# Altium schematic sheet FPGA_PWR.SchDoc(FPGA_PWR)
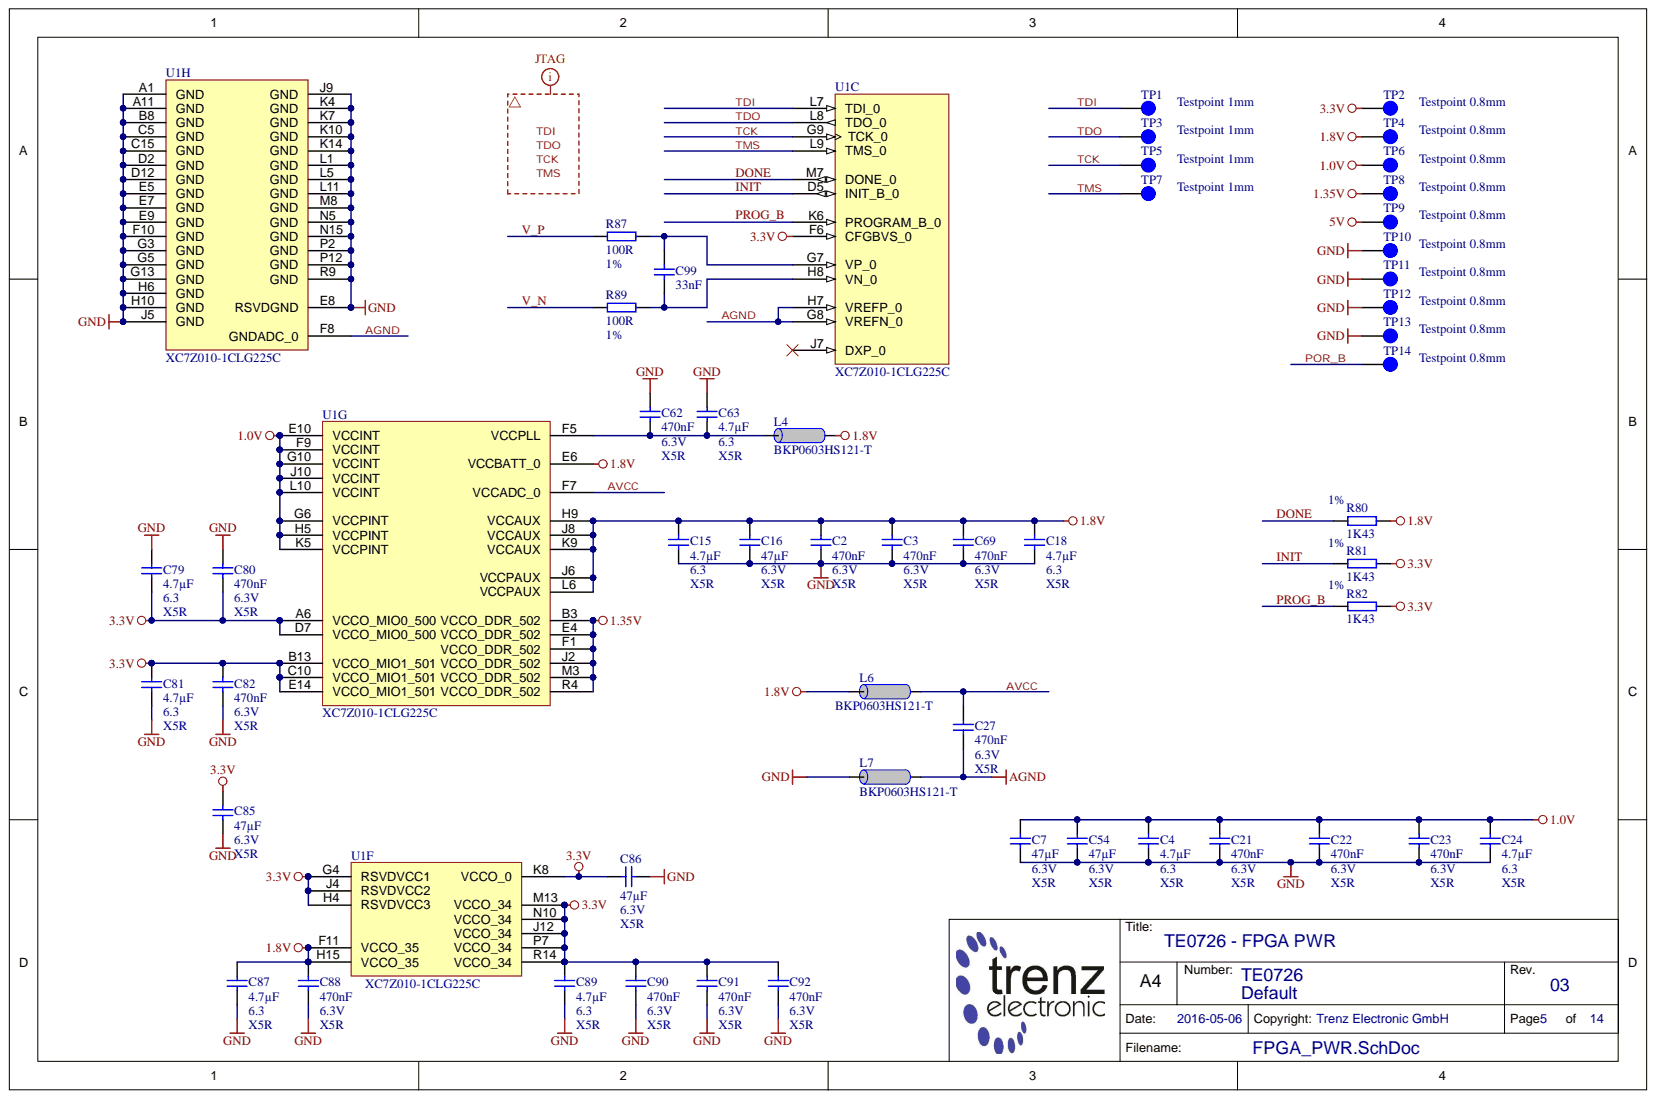
[diagram p0: FPGA_PWR.SchDoc]

## Parts
C2  470nF  Technology: X5R  Voltage: 6.3V  [CAPC0603X33N]
C3  470nF  Technology: X5R  Voltage: 6.3V  [CAPC0603X33N]
C4  4.7µF  Technology: X5R  Voltage: 6.3  [CAPC1005X60N]
C7  47µF  Technology: X5R  Voltage: 6.3V  [CAPC2012X135N]
C15  4.7µF  Technology: X5R  Voltage: 6.3  [CAPC1005X60N]
C16  47µF  Technology: X5R  Voltage: 6.3V  [CAPC2012X135N]
C18  4.7µF  Technology: X5R  Voltage: 6.3  [CAPC1005X60N]
C21  470nF  Technology: X5R  Voltage: 6.3V  [CAPC0603X33N]
C22  470nF  Technology: X5R  Voltage: 6.3V  [CAPC0603X33N]
C23  470nF  Technology: X5R  Voltage: 6.3V  [CAPC0603X33N]
C24  4.7µF  Technology: X5R  Voltage: 6.3  [CAPC1005X60N]
C27  470nF  Technology: X5R  Voltage: 6.3V  [CAPC0603X33N]
C54  47µF  Technology: X5R  Voltage: 6.3V  [CAPC2012X135N]
C62  470nF  Technology: X5R  Voltage: 6.3V  [CAPC0603X33N]
C63  4.7µF  Technology: X5R  Voltage: 6.3  [CAPC1005X60N]
C69  470nF  Technology: X5R  Voltage: 6.3V  [CAPC0603X33N]
C79  4.7µF  Technology: X5R  Voltage: 6.3  [CAPC1005X60N]
C80  470nF  Technology: X5R  Voltage: 6.3V  [CAPC0603X33N]
C81  4.7µF  Technology: X5R  Voltage: 6.3  [CAPC1005X60N]
C82  470nF  Technology: X5R  Voltage: 6.3V  [CAPC0603X33N]
C85  47µF  Technology: X5R  Voltage: 6.3V  [CAPC2012X135N]
C86  47µF  Voltage: 6.3V  [CAPC2012X135N]
C87  4.7µF  Technology: X5R  Voltage: 6.3  [CAPC1005X60N]
C88  470nF  Technology: X5R  Voltage: 6.3V  [CAPC0603X33N]
C89  4.7µF  Technology: X5R  Voltage: 6.3  [CAPC1005X60N]
C90  470nF  Technology: X5R  Voltage: 6.3V  [CAPC0603X33N]
C91  470nF  Technology: X5R  Voltage: 6.3V  [CAPC0603X33N]
C92  470nF  Technology: X5R  Voltage: 6.3V  [CAPC0603X33N]
C99  33nF  [CAPC1005X55N]
L4  BKP0603HS121-T  [INDC0603X33N]
L6  BKP0603HS121-T  [INDC0603X33N]
L7  BKP0603HS121-T  [INDC0603X33N]
R80  1K43  Tolerance: 1%  [RESC1005X40N]
R81  1K43  Tolerance: 1%  [RESC1005X40N]
R82  1K43  Tolerance: 1%  [RESC1005X40N]
R87  100R  Tolerance: 1%  [RESC1005X40N]
R89  100R  Tolerance: 1%  [RESC1005X40N]
TP1  Testpoint 1mm  [TP_1mm]
TP2  Testpoint 0.8mm  [TP_0.8mm]
TP3  Testpoint 1mm  [TP_1mm]
TP4  Testpoint 0.8mm  [TP_0.8mm]
TP5  Testpoint 1mm  [TP_1mm]
TP6  Testpoint 0.8mm  [TP_0.8mm]
TP7  Testpoint 1mm  [TP_1mm]
TP8  Testpoint 0.8mm  [TP_0.8mm]
TP9  Testpoint 0.8mm  [TP_0.8mm]
TP10  Testpoint 0.8mm  [TP_0.8mm]
TP11  Testpoint 0.8mm  [TP_0.8mm]
TP12  Testpoint 0.8mm  [TP_0.8mm]
TP13  Testpoint 0.8mm  [TP_0.8mm]
TP14  Testpoint 0.8mm  [TP_0.8mm]
U1  XC7Z010-1CLG225C  [BGA225C80P15X15_1300X1300X150B40N]  (parts C,F,G,H)

## Nets
1.0V: C4.1 C7.1 C21.2 C22.2 C23.2 C24.1 C54.1 TP6.1 U1.E10(VCCINT) U1.F9(VCCINT) U1.G6(VCCPINT) U1.G10(VCCINT) U1.H5(VCCPINT) U1.J10(VCCINT) U1.K5(VCCPINT) U1.L10(VCCINT)
1.8V: C2.2 C3.1 C15.1 C16.1 C18.1 C69.1 C87.1 C88.2 L4.1 L6.2 R80.2 TP4.1 U1.E6(VCCBATT_0) U1.F11(VCCO_35) U1.H9(VCCAUX) U1.H15(VCCO_35) U1.J6(VCCPAUX) U1.J8(VCCAUX) U1.K9(VCCAUX) U1.L6(VCCPAUX)
1.35V: TP8.1 U1.B3(VCCO_DDR_502) U1.E4(VCCO_DDR_502) U1.F1(VCCO_DDR_502) U1.J2(VCCO_DDR_502) U1.M3(VCCO_DDR_502) U1.R4(VCCO_DDR_502)
3.3V: C79.1 C80.2 C81.1 C82.2 C85.1 C86.2 C89.1 C90.2 C91.2 C92.2 R81.2 R82.2 TP2.1 U1.A6(VCCO_MIO0_500) U1.B13(VCCO_MIO1_501) U1.C10(VCCO_MIO1_501) U1.D7(VCCO_MIO0_500) U1.E14(VCCO_MIO1_501) U1.F6(CFGBVS_0) U1.G4(RSVDVCC1) U1.H4(RSVDVCC3) U1.J4(RSVDVCC2) U1.J12(VCCO_34) U1.K8(VCCO_0) U1.M13(VCCO_34) U1.N10(VCCO_34) U1.P7(VCCO_34) U1.R14(VCCO_34)
5V: TP9.1
GND: C2.1 C3.2 C4.2 C7.2 C15.2 C16.2 C18.2 C21.1 C22.1 C23.1 C24.2 C54.2 C62.1 C63.1 C69.2 C79.2 C80.1 C81.2 C82.1 C85.2 C86.1 C87.2 C88.1 C89.2 C90.1 C91.1 C92.1 L7.2 TP10.1 TP11.1 TP12.1 TP13.1 U1.A1(GND) U1.A11(GND) U1.B8(GND) U1.C5(GND) U1.C15(GND) U1.D2(GND) U1.D12(GND) U1.E5(GND) U1.E7(GND) U1.E8(RSVDGND) U1.E9(GND) U1.F10(GND) U1.G3(GND) U1.G5(GND) U1.G13(GND) U1.H6(GND) U1.H10(GND) U1.J5(GND) U1.J9(GND) U1.K4(GND) U1.K7(GND) U1.K10(GND) U1.K14(GND) U1.L1(GND) U1.L5(GND) U1.L11(GND) U1.M8(GND) U1.N5(GND) U1.N15(GND) U1.P2(GND) U1.P12(GND) U1.R9(GND)
AGND: C27.1 L7.1 U1.F8(GNDADC_0) U1.G8(VREFN_0) U1.H7(VREFP_0)
AVCC: C27.2 L6.1 U1.F7(VCCADC_0)
DONE: R80.1 U1.M7(DONE_0)
INIT: R81.1 U1.D5(INIT_B_0)
POR_B: TP14.1
PROG_B: R82.1 U1.K6(PROGRAM_B_0)
TCK: TP5.1 U1.G9(TCK_0)
TDI: TP1.1 U1.L7(TDI_0)
TDO: TP3.1 U1.L8(TDO_0)
TMS: TP7.1 U1.L9(TMS_0)
V_N: R89.1
V_P: R87.1
NetC62_2: C62.2 C63.2 L4.2 U1.F5(VCCPLL)
NetC99_1: C99.1 R89.2 U1.H8(VN_0)
NetC99_2: C99.2 R87.2 U1.G7(VP_0)
N00210:

Not connected: U1 J7(DXP_0)

## Library data of the parts
C2 C3 C21-C23 C27 C62 C69 C80 C82 C88 C90-C92 = 24921: KON 470nF X5R 6.3V SMD 20%; Database Table Name: C_0603_0201; Mixed: SMT; Preferred: Yes; Value: 470n; AN: 24921; Case: 0603 (0201); Component Kind: Standard; Library Name: TE.DbLib; Tolerance: 20%
C4 C15 C18 C24 C63 C79 C81 C87 C89 = 24719: KON 4.7µF 6.3V X5R SMD 20%; Database Table Name: C_1005_0402; Mixed: SMT; Preferred: Yes; Value: 4.7u; AN: 24719; Case: 1005 (0402); Component Kind: Standard; Library Name: TE.DbLib; Tolerance: 20%
C7 C16 C54 C85 C86 = 24718: KON 47µF 6,3V  X5R SMD 20%; Database Table Name: C_2012_0805; Mixed: SMT; Preferred: Yes; Value: 47u; AN: 24718; Case: 2012 (0805); Component Kind: Standard; Library Name: TE.DbLib; Tolerance: 20%
  C86: Technology: X5R
C99 = 23916: KON 33nF 25V X7R SMD; Database Table Name: C_1005_0402; Mixed: SMT; Preferred: Yes; Value: 33n; AN: 23916; Case: 1005 (0402); Component Kind: Standard; Library Name: TE.DbLib; Technology: X7R; Voltage: 25V
L4 L6 L7 = 25393: Ferritdurchführung SMD 0201; Database Table Name: L_0603_0201; Mixed: SMT; Preferred: Yes; Tolerance: 25%; AN: 25393; Case: 0603 (0201); Component Kind: Standard; H-ART: BKP0603HS121-T; Library Name: TE.DbLib; Trenz-Stock: Yes; HelpURL: B:\AD\adlib\datasheet\MULTILAYER CHIP BEAD INDUCTORS BK
R80-R82 = 23473: WID SMD 1K43 1% 0,063W; Database Table Name: R_1005_0402; Mixed: SMT; Value: 1.43K; AN: 23473; Case: 1005 (0402); Component Kind: Standard; Library Name: TE.DbLib; Technology: TK100
R87 R89 = 22507: WID SMD 100R 1% 0,063W; Database Table Name: R_1005_0402; Mixed: SMT; Preferred: Yes; Value: 100; AN: 22507; Case: 1005 (0402); Component Kind: Standard; Library Name: TE.DbLib; Technology: TK100
TP1 TP3 TP5 TP7 = 25063: Database Table Name: PCB; Mixed: SMT; AN: 25063; Component Kind: Standard; Description: Testpoint 1mm; Library Name: TE.DbLib
TP2 TP4 TP6 TP8-TP14 = 26289: Database Table Name: PCB; Mixed: 1; AN: 26289; Component Kind: Standard; Description: Testpoint 0.8mm; Library Name: TE.DbLib
U1 = 26308: Database Table Name: PROG_LOGIC; Mixed: SMT; Preferred: Yes; AN: 26308; Case: CLG225; Component Kind: Standard; Description: XC7Z010-1CLG225C; H-ART: XC7Z010-1CLG225C; Library Name: TE.DbLib; Trenz-Stock: Yes; HelpURL: B:\AD\adlib\datasheet\XC7\Zynq
